# Altium schematic sheet ArduinoMega2560.SchDoc(ArduinoMega2560)
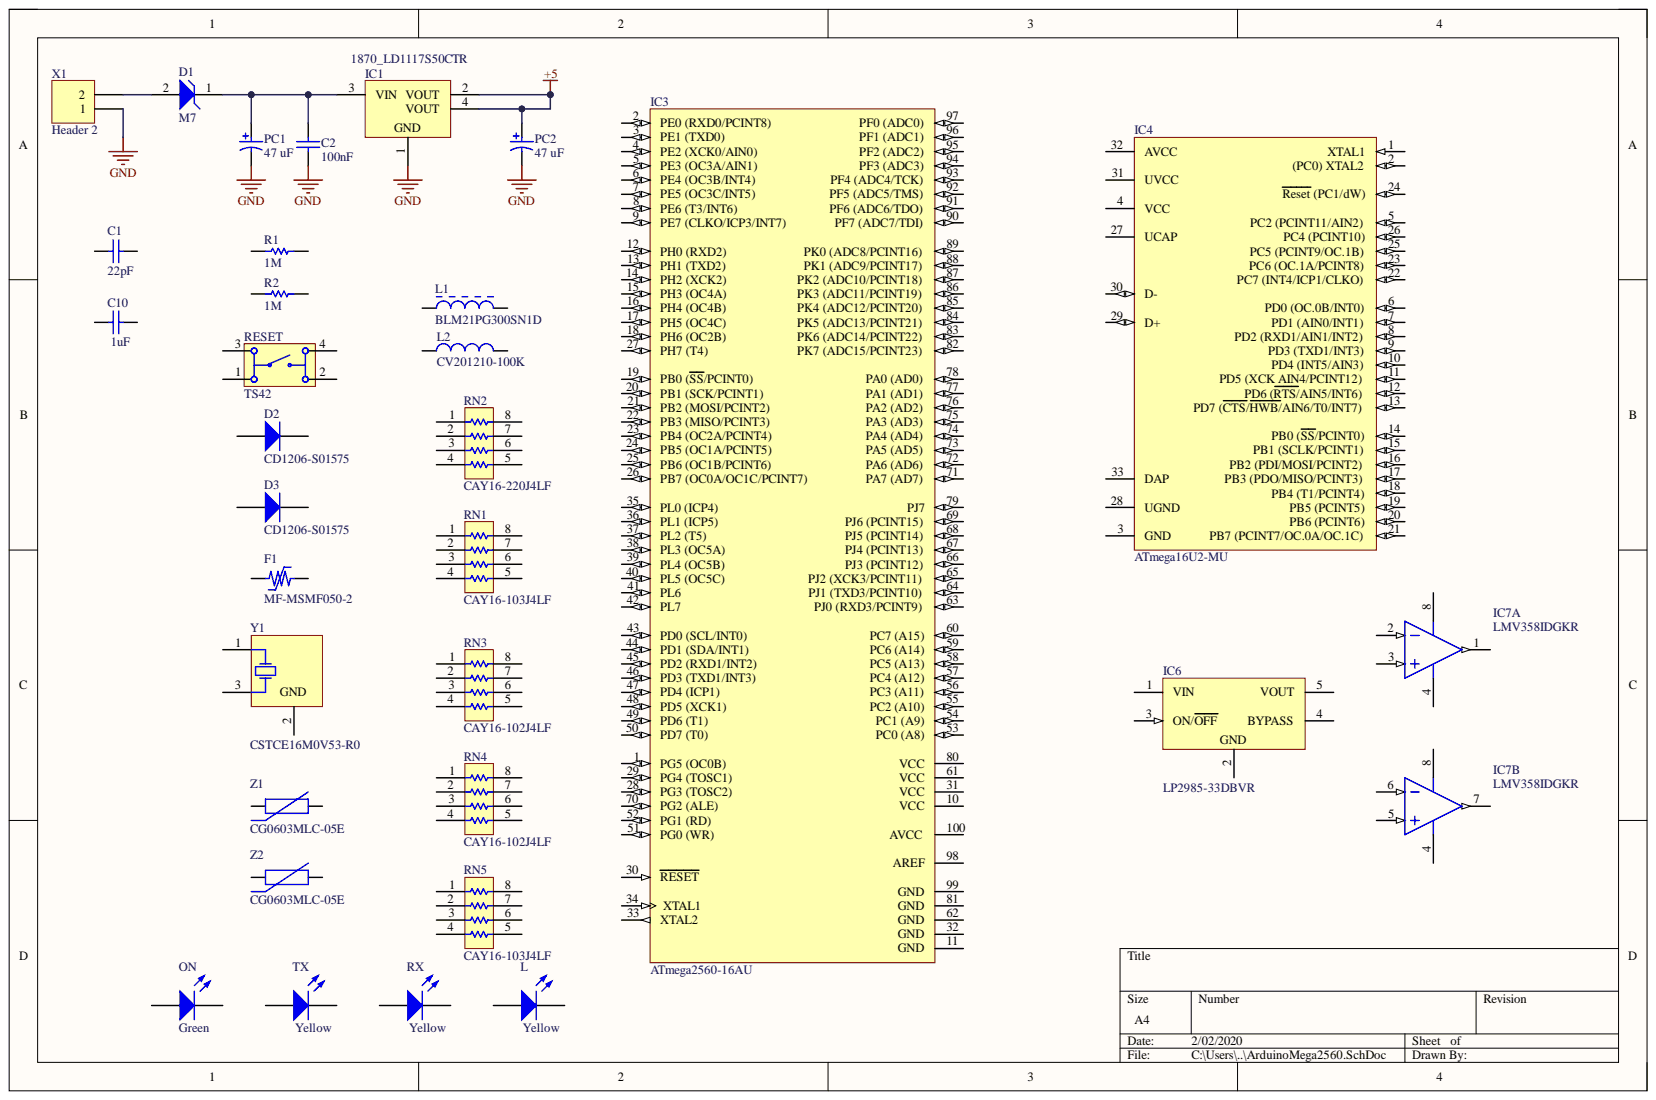
[diagram p0: ArduinoMega2560.SchDoc]

## Parts
C1  22pF  [CAPC1608X90X35ML10T15]
C2  100nF  [CAPC1608X90X20LL10]
C10  1uF  [CAPC1608X90X35LL10T15]
D1  M7  [ONSC-SMB-2-403A-03_H_V]
D2  CD1206-S01575  [BOUR-CD1206-S01575-2_L]
D3  CD1206-S01575  [BOUR-CD1206-S01575-2_L]
F1  MF-MSMF050-2  [BOUR-MF-MSMF050-2_V]
IC1  1870_LD1117S50CTR  [SOT-223_N]
IC3  ATmega2560-16AU  [100A_M]
IC4  ATmega16U2-MU  [32PG_N]
IC6  LP2985-33DBVR  [DBV0005A_M]
IC7  LMV358IDGKR  [DGK0008A_M]  (parts A,B)
L  Yellow  [0805_A]
L1  BLM21PG300SN1D  [MURA-BLM21-B40-CHIP-2_V3]
L2  CV201210-100K  [MURA-IND0805_L]
ON  Green  [0805_A]
PC1  47 uF  [PNSC-FP-V-D_V]
PC2  47 uF  [PNSC-FP-V-D_V]
R1  1M  [RESC1609X50X30LL10T20]
R2  1M  [RESC1609X50X30LL10T20]
RESET  TS42  [430152070826]
RN1  CAY16-103J4LF  [BOUR-CAY16-J4_V]
RN2  CAY16-220J4LF  [BOUR-CAY16-J4_V]
RN3  CAY16-102J4LF  [BOUR-CAY16-J4_V]
RN4  CAY16-102J4LF  [BOUR-CAY16-J4_V]
RN5  CAY16-103J4LF  [BOUR-CAY16-J4_V]
RX  Yellow  [0805_A]
TX  Yellow  [0805_A]
X1  Header 2  [HDR1X2]
Y1  CSTCE16M0V53-R0  [MURA-CSTCE_V-3_V]
Z1  CG0603MLC-05E  [BOUR-CG0603-2_V]
Z2  CG0603MLC-05E  [BOUR-CG0603-2_V]

## Nets
+5: IC1.2(VOUT) IC1.4(VOUT) PC2.1
GND: C2.1 IC1.1 PC1.2 PC2.2 X1.1
NetC2_2: C2.2 D1.1 IC1.3(VIN) PC1.1
NetD1_2: D1.2 X1.2

Not connected: C1 1 2; C10 1 2; D2 1 2; D3 1 2; F1 1 2; IC3 1(PG5_(OC0B)) 2(PE0_(RXD0/PCINT8)) 3(PE1_(TXD0)) 4(PE2_(XCK0/AIN0)) 5(PE3_(OC3A/AIN1)) 6(PE4_(OC3B/INT4)) 7(PE5_(OC3C/INT5)) 8(PE6_(T3/INT6)) 9(PE7_(CLKO/ICP3/INT7)) 10(VCC) 11(GND) 12(PH0_(RXD2)) 13(PH1_(TXD2)) 14(PH2_(XCK2)) 15(PH3_(OC4A)) 16(PH4_(OC4B)) 17(PH5_(OC4C)) 18(PH6_(OC2B)) 19(PB0_(SS/PCINT0)) 20(PB1_(SCK/PCINT1)) 21(PB2_(MOSI/PCINT2)) 22(PB3_(MISO/PCINT3)) 23(PB4_(OC2A/PCINT4)) 24(PB5_(OC1A/PCINT5)) 25(PB6_(OC1B/PCINT6)) 26(PB7_(OC0A/OC1C/PCINT7)) 27(PH7_(T4)) 28(PG3_(TOSC2)) 29(PG4_(TOSC1)) 30(RESET) 31(VCC) 32(GND) 33(XTAL2) 34(XTAL1) 35(PL0_(ICP4)) 36(PL1_(ICP5)) 37(PL2_(T5)) 38(PL3_(OC5A)) 39(PL4_(OC5B)) 40(PL5_(OC5C)) 41(PL6) 42(PL7) 43(PD0_(SCL/INT0)) 44(PD1_(SDA/INT1)) 45(PD2_(RXD1/INT2)) 46(PD3_(TXD1/INT3)) 47(PD4_(ICP1)) 48(PD5_(XCK1)) 49(PD6_(T1)) 50(PD7_(T0)) 51(PG0_(WR)) 52(PG1_(RD)) 53(PC0_(A8)) 54(PC1_(A9)) 55(PC2_(A10)) 56(PC3_(A11)) 57(PC4_(A12)) 58(PC5_(A13)) 59(PC6_(A14)) 60(PC7_(A15)) 61(VCC) 62(GND) 63(PJ0_(RXD3/PCINT9)) 64(PJ1_(TXD3/PCINT10)) 65(PJ2_(XCK3/PCINT11)) 66(PJ3_(PCINT12)) 67(PJ4_(PCINT13)) 68(PJ5_(PCINT14)) 69(PJ6_(PCINT15)) 70(PG2_(ALE)) 71(PA7_(AD7)) 72(PA6_(AD6)) 73(PA5_(AD5)) 74(PA4_(AD4)) 75(PA3_(AD3)) 76(PA2_(AD2)) 77(PA1_(AD1)) 78(PA0_(AD0)) 79(PJ7) 80(VCC) 81(GND) 82(PK7_(ADC15/PCINT23)) 83(PK6_(ADC14/PCINT22)) 84(PK5_(ADC13/PCINT21)) 85(PK4_(ADC12/PCINT20)) 86(PK3_(ADC11/PCINT19)) 87(PK2_(ADC10/PCINT18)) 88(PK1_(ADC9/PCINT17)) 89(PK0_(ADC8/PCINT16)) 90(PF7_(ADC7/TDI)) 91(PF6_(ADC6/TDO)) 92(PF5_(ADC5/TMS)) 93(PF4_(ADC4/TCK)) 94(PF3_(ADC3)) 95(PF2_(ADC2)) 96(PF1_(ADC1)) 97(PF0_(ADC0)) 98(AREF) 99(GND) 100(AVCC); IC4 1(XTAL1) 2((PC0)_XTAL2) 3(GND) 4(VCC) 5(PC2_(PCINT11/AIN2)) 6(PD0_(OC.0B/INT0)) 7(PD1_(AIN0/INT1)) 8(PD2_(RXD1/AIN1/INT2)) 9(PD3_(TXD1/INT3)) 10(PD4_(INT5/AIN3)) 11(PD5_(XCK_AIN4/PCINT12)) 12(PD6_(RTS/AIN5/INT6)) 13(PD7_(CTS/HWB/AIN6/T0/INT7)) 14(PB0_(SS/PCINT0)) 15(PB1_(SCLK/PCINT1)) 16(PB2_(PDI/MOSI/PCINT2)) 17 18(PB4_(T1/PCINT4)) 19(PB5_(PCINT5)) 20(PB6_(PCINT6)) 21 22(PC7_(INT4/ICP1/CLKO)) 23(PC6_(OC.1A/PCINT8)) 24(Reset_(PC1/dW)) 25(PC5_(PCINT9/OC.1B)) 26(PC4_(PCINT10)) 27(UCAP) 28(UGND) 29(D+) 30(D-) 31(UVCC) 32(AVCC) 33(DAP); IC6 1(VIN) 2 3(ON/OFF) 4(BYPASS) 5(VOUT); IC7 1 2 3 4 5 6 7 8; L 1 2; L1 1 2; L2 1 2; ON 1 2; R1 1 2; R2 1 2; RESET 1 2 3 4; RN1 1 2 3 4 5 6 7 8; RN2 1 2 3 4 5 6 7 8; RN3 1 2 3 4 5 6 7 8; RN4 1 2 3 4 5 6 7 8; RN5 1 2 3 4 5 6 7 8; RX 1 2; TX 1 2; Y1 1 2 3; Z1 1 2; Z2 1 2

## Library data of the parts
C1 = CMP-2000-04945-1: Chip Capacitor, 22 pF, +/- 5%, 50 V, -55 to 125 degC, 0603 (1608 Metric), RoHS, Tape and Reel; Manufacturer: Murata; Dielectric: C0G; Rated Voltage: 50V; Capacitance: 22pF; Case Size/Dimension: 0603; Component Kind: Standard; Datasheet URL: https://datasheet.ciiva.com/11786/0900766b807a1cab-11786398.pdf; Datasheet Version: Jul-06; Library Name: Altium Content Vault; Manufacturer URL: http://www.murata.com/; Package Description: 2-Pin Surface Mount Device, Body 1.6 x 0.8 mm; Package Reference: 603; Tolerance (%): ±5%
C2 = CMP-2000-07546-1: Chip Capacitor, 0.1 uF, +/- 10%, 50 V, -55 to 125 degC, 0603 (1608 Metric), RoHS, Tape and Reel; Manufacturer: TDK; Dielectric: X7R; Voltage: 50V; Capacitance: 100nF; Case Code (Imperial): 0603; Case Code (Metric): 1608; Case/Package: 0603; Component Kind: Standard; Composition: Ceramic; Datasheet URL: https://product.tdk.com/info/en/catalog/datasheets/mlcc_automotive_general_en.pdf; Datasheet Version: 12/2014; Depth: 0.8mm; Height: 0.8mm; Lead Free: LeadFree; Length: 1.6mm; Library Name: Altium Content Vault; Manufacturer URL: http://www.tdk.com/; Max Operating Temperature: 125degC; Min Operating Temperature: -55degC; Mount: SurfaceMount; Mounting Technology: SurfaceMount; Package Description: 2-Pin Surface Mount Device, Body 1.6 x 0.8 mm; Package Quantity: 1; Package Reference: 603; Packaging: TapeandReel; Radiation Hardening: No; REACH SVHC: NoSVHC; RoHSCompliant: True; Termination: SMD/SMT; Thickness: 0.035inch; Tolerance: 10%; Voltage Rating: 50V; Voltage Rating (DC): 50V; Weight: 7.1E-05oz; Width: 0.8mm
C10 = CMP-2006-02459-1: Manufacturer: Murata; Part Number: GRM188R61E105KA12D; Component Kind: Standard; Library Name: Altium Content Vault
D1 = CMP-1061-00363-1: 1A 40V 600 W Peak Power Zener Transient Voltage Suppressor, Unidirectional, 2-Pin SMB, Pb-Free, Tape and Reel  1SMB24AT3G; Manufacturer: On Semiconductor; IR Max (uA): 5; PPK Max (W): 600; V(BR) Typ (V): 28.1; Component Kind: Standard; ComponentLink1Description: Manufacturer URL; ComponentLink1URL: http://www.onsemi.com/; ComponentLink2Description: Datasheet; ComponentLink2URL: http://www.onsemi.com/pub_link/Collateral/1SMB5.0AT3-D.PDF; ComponentLink3Description: Package Specification; ComponentLink3URL: http://www.onsemi.com/pub_link/Collateral/1SMB5913BT3-D.PDF; DatasheetVersion: Rev. 13; Direction: Unidirectional; Library Name: Altium Content Vault; Mounting Technology: Surface Mount; PackageDescription: 2-Pin Small Molded Package, J-Bend Lead, Body 4.33 x 3.625 mm; PackageReference: SMB-2-403A-03_H; PackageVersion: Rev. H, 05/2011; Packing: Tape and Reel; PartNumber: 1SMB24AT3G; RoHS: Pb-Free
D2 D3 = CMP-0583-00001-2: 75 V Small Signal Switching Diode, 150 mA, 2.5 uA, 1026 Molded Package, RoHS, Tape and Reel; Manufacturer: Bourns; Average Forward Current: 150 mA; Capacitance Between Terminals (Max.): 3 pF; Forward Voltage (Max.): 1.00 V; Reverse Current (Max.): 2.5 uA; Reverse Recovery Time (Max.): 4 nS; Reverse Voltage: 75 V; Component Kind: Standard; ComponentLink1Description: Manufacturer URL; ComponentLink1URL: http://www.bourns.com/; ComponentLink2Description: Datasheet; ComponentLink2URL: http://www.bourns.com/data/global/pdfs/CD1206_S01575.pdf; ComponentLink3Description: Package Specification; ComponentLink3URL: http://www.bourns.com/data/global/pdfs/CD1206_S01575.pdf; DatasheetVersion: Rev. 06/11; Library Name: Altium Content Vault; Mounting Technology: Surface Mount; PackageDescription: 2-Pin SMD, Body 3.2 x 1.5 mm; PackageReference: CD1206-S01575-2; Packing: Tape and Reel; PartNumber: CD1206-S01575; RoHS: TRUE
F1 = CMP-2100-03653-1: PTC Resettable Fuse, 15 V, 500 mA, -40 to 85 degC, 2-Pin SMD, RoHS, Tape and Reel; Manufacturer: Bourns; Current Rating: 100A; Power Dissipation: 800mW; Resistance: 150mOhm; Supply Voltage: 15V; Case Code (Imperial): 1812; Case Code (Metric): 4532; Case/Package: 1812; Component Kind: Standard; Contact Plating: Gold; Datasheet URL: http://www.bourns.com/docs/Product-Datasheets/mfmsmf.pdf; Datasheet Version: Rev. AG, 08/2016; Height: 0.85mm; Lead Free: LeadFree; Length: 4.73mm; Library Name: Altium Content Vault; Manufacturer URL: http://www.bourns.com/; Max Current Rating: 100A; Max Operating Temperature: 85degC; Min Operating Temperature: -40degC; Mount: SurfaceMount; Number of Terminals: 2; Package Description: 2-Pin Surface Mount Device, Body 4.55 x 3.24 mm; Package Quantity: 2000; Package Reference: MF-MSMF050-2; Packaging: TapeandReel; Radiation Hardening: No; REACH SVHC: NoSVHC; RoHSCompliant: true; Termination: SMD/SMT; Voltage Rating: 15V; Voltage Rating (DC): 15V; Width: 3.41mm
IC1 = CMP-0244-00311-1: Low-dropout linear regulator 5V -LM1117IMPX-5.0  Fixed Low Drop Positive Voltage Regulator, 5V, 4-Pin SOT-223, Tape and Reel; Component Kind: Standard; ComponentLink1Description: Manufacturer URL; ComponentLink1URL: http://www.st.com; ComponentLink2Description: Datasheet; ComponentLink2URL: http://www.st.com/internet/com/TECHNICAL_RESOURCES/TECHNICAL_LITERATURE/DATASHEET/CD00000544.pdf; DatasheetVersion: 1/11/2011; Library Name: Altium Content Vault; PackageDescription: 4-Pin SOT-223 Package, 6.5 x 3.5 mm Body, 2.3 mm Pitch, 7 mm Lead Span; PackageReference: SOT-223; PackageVersion: 2010
IC3 = CMP-0095-00210-2: 8-bit AVR Microcontroller, 4.5-5.5V, 16MHz, 256KB Flash, 4KB EEPROM, 8KB SRAM, 86 GPIO pins, 100-pin TQFP, Industrial Grade (-40°C to 85°C), Pb-Free; Code_JEDEC: MS-026-AED; Component Kind: Standard; ComponentLink1Description: Manufacturer URL; ComponentLink1URL: http://www.atmel.com/; ComponentLink2Description: ATmega640/1280/1281/2560/2561 Datasheet; ComponentLink2URL: http://www.atmel.com/dyn/resources/prod_documents/doc2549.pdf; ComponentLink3Description: ATmega640/1280/1281/2560/2561 Summary; ComponentLink3URL: http://www.atmel.com/dyn/resources/prod_documents/2549S.pdf; DatasheetVersion: revN, May-2011; Library Name: Altium Content Vault; PackageDescription: 100-Pin Thin Profile Plastic Quad Flat Pack (TQFP), 14 x 14 x 1.0 mm Body, 0.5 mm Pitch; PackageReference: 100A; PackageVersion: revD, Oct-2010; RevisionNote: DatasheetVersion & PackageVersion updated. URLs added.
IC4 = CMP-0095-00115-3: 8-bit AVR Microcontroller, 2.7-5.5V, 16KB Flash, 512 Bytes EEPROM, 512 Bytes SRAM, 32-pin VQFN, Industrial Grade (-40°C to 85°C); Code_JEDEC: MO-220WHHD-2; Component Kind: Standard; ComponentLink1Description: Manufacturer URL; ComponentLink1URL: http://www.atmel.com/; ComponentLink2Description: ATmega8U2/16U2/32U2 Datasheet; ComponentLink2URL: http://www.atmel.com/dyn/resources/prod_documents/doc7799.pdf; ComponentLink3Description: ATmega8U2/16U2/32U2 Summary; ComponentLink3URL: http://www.atmel.com/dyn/resources/prod_documents/7799S.pdf; DatasheetVersion: revD, Nov-2010; Library Name: Altium Content Vault; PackageDescription: 32-Pin Quad Flat No-Lead (QFN) Sawn,  5 x 5 x 1.0 mm Body, Pitch 0.50 mm, 3.60mm Exposed Pad; PackageReference: 32PG; PackageVersion: revA, Oct-2008; PartNumber: ATmega16U2-MU; RevisionNote: Schematic symbol corrected
IC6 = CMP-0758-00080-2: Single Output Low Noise LDO, 150 mA, Fixed 3.3 V Output, 1.5% Tolerance, 2.2 to 16 V Input, Low IQ, 5-Pin SOT-23 (DBV), -40 to 125 degC, Green (RoHS & no Sb/Br), Tape and Reel; Manufacturer: Texas Instruments; Accuracy (%): 1.5; Fixed Output Options (V): 3.3; Iout (Max) (A): 0.15; Iq (Typ) (mA): 0.85; Output Options: Fixed Output; Vdo (Typ) (mV): 280; Component Kind: Standard; ComponentLink1Description: Manufacturer URL; ComponentLink1URL: http://www.ti.com/; ComponentLink2Description: Datasheet; ComponentLink2URL: http://www.ti.com/lit/ds/symlink/lp2985-50.pdf; ComponentLink3Description: Package Specification; ComponentLink3URL: http://www.ti.com/litv/pdf/mpds018j; DatasheetVersion: SLVS522N; Library Name: Altium Content Vault; Mounting Technology: Surface Mount; Operating Temperature Range (degC): -40 to 125; PackageDescription: 5-Pin Plastic Small Outline, Body 2.9 x 1.6 mm, Pitch 0.95 mm; PackageReference: DBV0005A; PackageVersion: 4073253-4/L; Packing: Tape and Reel; PartNumber: LP2985-33DBVR; RoHS: TRUE; Vin (V): 2.2 to 16
IC7 = CMP-2100-03651-1: Low-Voltage Rail-to-Rail Output Operational Amplifier, 2.7 to 5.5 V, -40 to 125 degC, 8-Pin VSSOP (DGK), Green (RoHS & no Sb/Br), Tape and Reel; Manufacturer: Texas Instruments; Bandwidth: 1MHz; Common Mode Rejection Ratio: 50dB; Input Bias Current: 250nA; Input Offset Voltage (Vos): 7mV; Max Supply Voltage: 5.5V; Min Supply Voltage: 2.7V; Nominal Supply Current: 440uA; Number of Amplifiers: 2; Operating Supply Current: 210uA; Output Current: 160mA; Slew Rate: 1V/us; Supply Voltage: 5V; Voltage Gain: 100dB; Case/Package: MSOP; Component Kind: Standard; Contact Plating: Gold; Datasheet URL: http://www.ti.com/lit/ds/symlink/lmv358.pdf; Datasheet Version: SLOS263W, 10/2014; Gain Bandwidth Product: 1MHz; Height: 0.97mm; Lead Free: LeadFree; Length: 3mm; Library Name: Altium Content Vault; Manufacturer URL: http://www.ti.com/; Max Operating Temperature: 85degC; Min Operating Temperature: -40degC; Mount: SurfaceMount; Number of Channels: 2; Number of Circuits: 2; Number of Elements: 2; Number of Pins: 8; Output Current per Channel: 160mA; Package Description: 8-Pin Thin Small Outline Package, Body 3 x 3 mm, Pitch 0.65 mm; Package Quantity: 1; Package Reference: DGK0008A; Package URL: http://www.ti.com/litv/pdf/mpds028d; Packaging: TapeandReel; Radiation Hardening: No; REACH SVHC: NoSVHC; RoHSCompliant: true; Unity Gain Bandwidth Product: 1MHz; Width: 3mm
L RX TX = CMP-1426-00012-1: 0044_KPT-2012YC  LED YELLOW - SMD - 0805  SMD mono-color Chip LED, WL-SMCW, Yellow; Manufacturer: Wuerth Elektronik; PartNumber: 150080YS75000; Color: Yellow; Component Kind: Standard; ComponentLink1Description: Manufacturer URL; ComponentLink1URL: http://www.we-online.com; ComponentLink2Description: Datasheet; ComponentLink2URL: http://katalog.we-online.com/led/datasheet/150080YS75000.pdf; Library Name: Altium Content Vault; PackageReference: 0805_A
L1 = CMP-0686-00620-2: Chip Ferrite Bead for Power Lines, 30 Ohm, 4000 mA, -55 to 125 degC, 2 x 1.25 x 1.05 mm SMD, Tape and Reel; Manufacturer: Murata; DC Resistance: 0.014 Ohm Max.; Impedance (at 100 MHz): 30 Ohm Typ.; Rated Current: 4000 mA; Component Kind: Standard; ComponentLink1Description: Manufacturer URL; ComponentLink1URL: http://www.murata.com/; ComponentLink2Description: Datasheet; ComponentLink2URL: http://www.murata.com/products/catalog/pdf/c31e.pdf; ComponentLink3Description: Package Specification; ComponentLink3URL: http://www.murata.com/products/catalog/pdf/c31e.pdf; DatasheetVersion: 08/2013; Library Name: Altium Content Vault; Mounting Technology: Surface Mount; Operating Temperature Range: -55 to 125 degC; PackageDescription: 2-Pin Chip, Body 2 x 1.25 mm; PackageReference: BLM21_H1050-2; Packing: Tape and Reel; PartNumber: BLM21PG300SN1D
L2 = CMP-2000-06587-1: Fixed Inductor, 10 uH, +/-20%, -55 to 125 degC, 2-Pin SMD (0805), RoHS, Tape and Reel; Manufacturer: Murata; DC Resistance: 0.6; Inductance-Nom: 10H; Rated Current-Max: 100mA; Tolerance (%): 20%; Case Size/Dimension: 0805; Component Kind: Standard; Datasheet URL: http://psearch.en.murata.com/inductor/product/LQM21FN100M70%23.pdf; Datasheet Version: 05/2016; Library Name: Altium Content Vault; Manufacturer URL: http://www.murata.com/; Mounting Technology: SurfaceMount; Package Description: 2-Pin Surface Mount Device, Body 2 x 1.25 mm; Package Reference: IND0805
ON = CMP-1426-00007-1: KPT-2012SGC CHIPLED_0805 LED GREEN - SMD-0805  SMD mono-color Chip LED, WL-SMCW, Green; Manufacturer: Wuerth Elektronik; PartNumber: 150080GS75000; Color: Green; Component Kind: Standard; ComponentLink1Description: Manufacturer URL; ComponentLink1URL: http://www.we-online.com; ComponentLink2Description: Datasheet; ComponentLink2URL: http://katalog.we-online.com/led/datasheet/150080GS75000.pdf; Library Name: Altium Content Vault; PackageReference: 0805_A
PC1 PC2 = CMP-2000-06216-1: Aluminum Electrolytic Capacitor, 47 uF, +/- 20%, 35 V, 2-Pin SMD (Size Code D), -55 to 105 degC, RoHS, Tape and Reel; Manufacturer: Panasonic; Capacitance: 47µF; ESR (Equivalent Series Resistance): 0.26O; Lifetime@Temperature: 2000Hrs@105°C; Rated Voltage: 35V; Ripple Current: 300mA; Case Size/Dimension: Ø6.3x5.8mm; Component Kind: Standard; Datasheet URL: http://industrial.panasonic.com/cdbs/www-data/pdf/RDE0000/ABA0000C1184.pdf; Datasheet Version: 03/2016; Library Name: Altium Content Vault; Manufacturer URL: http://www.panasonic.com/; Mounting Technology: SurfaceMount; Operating Temp Range: -55to+105°C; Operating Temp-Max: 105°C; Package Description: 2-Pin Electrolytic Aluminum Capacitor, Body 6.6 x 6.6 mm; Package Reference: FP-V-D; Package URL: http://industrial.panasonic.com/cdbs/www-data/pdf/RDE0000/DME0000COL48.pdf; Series: FP; Type: Can
R1 R2 = CMP-2000-03013-1: Manufacturer: Vishay Dale; Part Number: CRCW06031M00FKEA; Component Kind: Standard; Library Name: Altium Content Vault
RESET = CMP-1452-00005-1: WS-TASV SMD Tact Switch 6X6 mm; Manufacturer: Wuerth Elektronik; NoPins: 04; Component Kind: Standard; ComponentLink1Description: Manufacturer URL; ComponentLink1URL: http://www.we-online.com; ComponentLink2Description: Datasheet; ComponentLink2URL: http://katalog.we-online.de/em/datasheet/4301x2.pdf; Library Name: Altium Content Vault; PackageReference: 430152070826; PartNumber: 430152070826
RN1 RN5 = CMP-1747-00005-1: Chip Resistor Array, 10 KOhm, +/- 5%, 62.5 mW, -55 to 125 degC, 8-Pin SMD, RoHS, Tape and Reel; Case\Package: 0603; Power Rating: 100 mW; Resistance: 220 Ohm; Tolerance: 1%; Voltage Rating: 75 V; Case Code (Imperial): 0603; Case Code (Metric): 1608; Component Kind: Standard; ComponentLink1Description: Manufacturer URL; ComponentLink1URL: http://www.bourns.com/; ComponentLink2Description: Datasheet; ComponentLink2URL: http://www.bourns.com/docs/Product-Datasheets/CATCAY.pdf; DatasheetVersion: Jan-15; Height: 0.022 inch; Length: 0.063 inch; Library Name: Altium Content Vault; Max Operating Temperature: 155 degC; Min Operating Temperature: -55 degC; Mount: Surface Mount; PackageDescription: 8-Pin Surface Mount Device, Body 1.6 x 3.2 mm, Pitch 0.8 mm; PackageReference: CAY16-J4; Packaging: Tape and Reel; Pins: 2; Radiation Hardening: No; REACH SVHC: Yes; RoHSCompliant: true; Weight: 7.1E-05 oz; Width: 0.031 inch
RN2 = CMP-1747-00005-1: Chip Resistor Array, 22 Ohm, +/- 5%, 62.5 mW, -55 to 125 degC, 8-Pin SMD, RoHS, Tape and Reel; Case\Package: 0603; Power Rating: 100 mW; Resistance: 220 Ohm; Tolerance: 1%; Voltage Rating: 75 V; Case Code (Imperial): 0603; Case Code (Metric): 1608; Component Kind: Standard; ComponentLink1Description: Manufacturer URL; ComponentLink1URL: http://www.bourns.com/; ComponentLink2Description: Datasheet; ComponentLink2URL: http://www.bourns.com/docs/Product-Datasheets/CATCAY.pdf; DatasheetVersion: Jan-15; Height: 0.022 inch; Length: 0.063 inch; Library Name: Altium Content Vault; Max Operating Temperature: 155 degC; Min Operating Temperature: -55 degC; Mount: Surface Mount; PackageDescription: 8-Pin Surface Mount Device, Body 1.6 x 3.2 mm, Pitch 0.8 mm; PackageReference: CAY16-J4; Packaging: Tape and Reel; Pins: 2; Radiation Hardening: No; REACH SVHC: Yes; RoHSCompliant: true; Weight: 7.1E-05 oz; Width: 0.031 inch
RN3 RN4 = CMP-1747-00007-1: Chip Resistor Array, 1 KOhm, +/- 5%, 62.5 mW, -55 to 125 degC, 8-Pin SMD, RoHS, Tape and Reel; Case\Package: 0603; Power Rating: 100 mW; Resistance: 330 Ohm; Tolerance: 1%; Voltage Rating: 50 V; Case Code (Imperial): 0603; Case Code (Metric): 1608; Component Kind: Standard; ComponentLink1Description: Manufacturer URL; ComponentLink1URL: http://www.bourns.com/; ComponentLink2Description: Datasheet; ComponentLink2URL: http://www.bourns.com/pdfs/CATCAY.pdf; DatasheetVersion: Sep-14; Height: 0.45 mm; Length: 1.6 mm; Library Name: Altium Content Vault; Max Operating Temperature: 155 degC; Min Operating Temperature: -55 degC; Mount: Surface Mount; Package Quantity: 5000; PackageDescription: 8-Pin Surface Mount Device, Body 1.6 x 3.2 mm, Pitch 0.8 mm; PackageReference: CAY16-J4; Packaging: Tape and Reel; Pins: 2; REACH SVHC: No SVHC; RoHSCompliant: true; Voltage Rating (DC): 50 V; Width: 0.8 mm
X1 = Header 2: Header, 2-Pin; Component Kind: Standard; LatestRevisionDate: 17-Jul-2002; LatestRevisionNote: Re-released for DXP Platform.; Library Name: Miscellaneous Connectors.IntLib; Signal Integrity: Connector; Publisher: Altium Limited
Y1 = CMP-0588-00001-2: Ceramic Resonator, 16 MHz with Built-in Capacitance, Thickness Expander Mode, Initial Frequency Tolerance +/- 0.5%, Load Capacity 15 pF, -20 to 80 degC, 3.2 x 1.3 x 1 mm SMD, RoHS, Reel; Manufacturer: Murata; Load Capacity: 15 pF; Oscillating Frequency: 16 MHz; Component Kind: Standard; ComponentLink1Description: Manufacturer URL; ComponentLink1URL: http://www.murata.com/; ComponentLink2Description: Datasheet; ComponentLink2URL: http://www.murata.com/products/catalog/pdf/p16e.pdf; DatasheetVersion: Rev. P16E-22; Initial Frequency Tolerance: +/- 0.5%; Initial Tolerance: +/- 0.5%; Library Name: Altium Content Vault; Mounting Technology: Surface Mount; Operating Temperature Range: -20 to 80 degC; PackageDescription: 3-Pin SMD, Body 3.2 x 1.3 mm, Pitch 0.95 mm; PackageReference: CSTCE_V-3; Packing: Reel; PartNumber: CSTCE16M0V53-R0; RoHS: TRUE
Z1 Z2 = CMP-0584-00001-2: ESD Protector, 5 V Supply, -40 to 85 degC, 1.6 x 0.8 x 0.55 mm SMD, RoHS, Tape and Reel; Manufacturer: Bourns; CO: 0.5 pF; RT: 1 ns; VC: 20 V; VDC: 5 V; VT: 150 V; Component Kind: Standard; ComponentLink1Description: Manufacturer URL; ComponentLink1URL: http://www.bourns.com/; ComponentLink2Description: Datasheet; ComponentLink2URL: http://www.bourns.com/data/global/pdfs/MLC.pdf; DatasheetVersion: Rev. S; Library Name: Altium Content Vault; Mounting Technology: Surface Mount; Operating Temperature: -40 to 85 degC; PackageDescription: 2-Pin SMD, Body 1.6 x 0.8 mm, Pitch 1.52 mm; PackageReference: CG0603-2; Packing: Tape and Reel; PartNumber: CG0603MLC-05E; RoHS: TRUE
